# Altium schematic sheet 2_ESP32.SchDoc(2_ESP32)
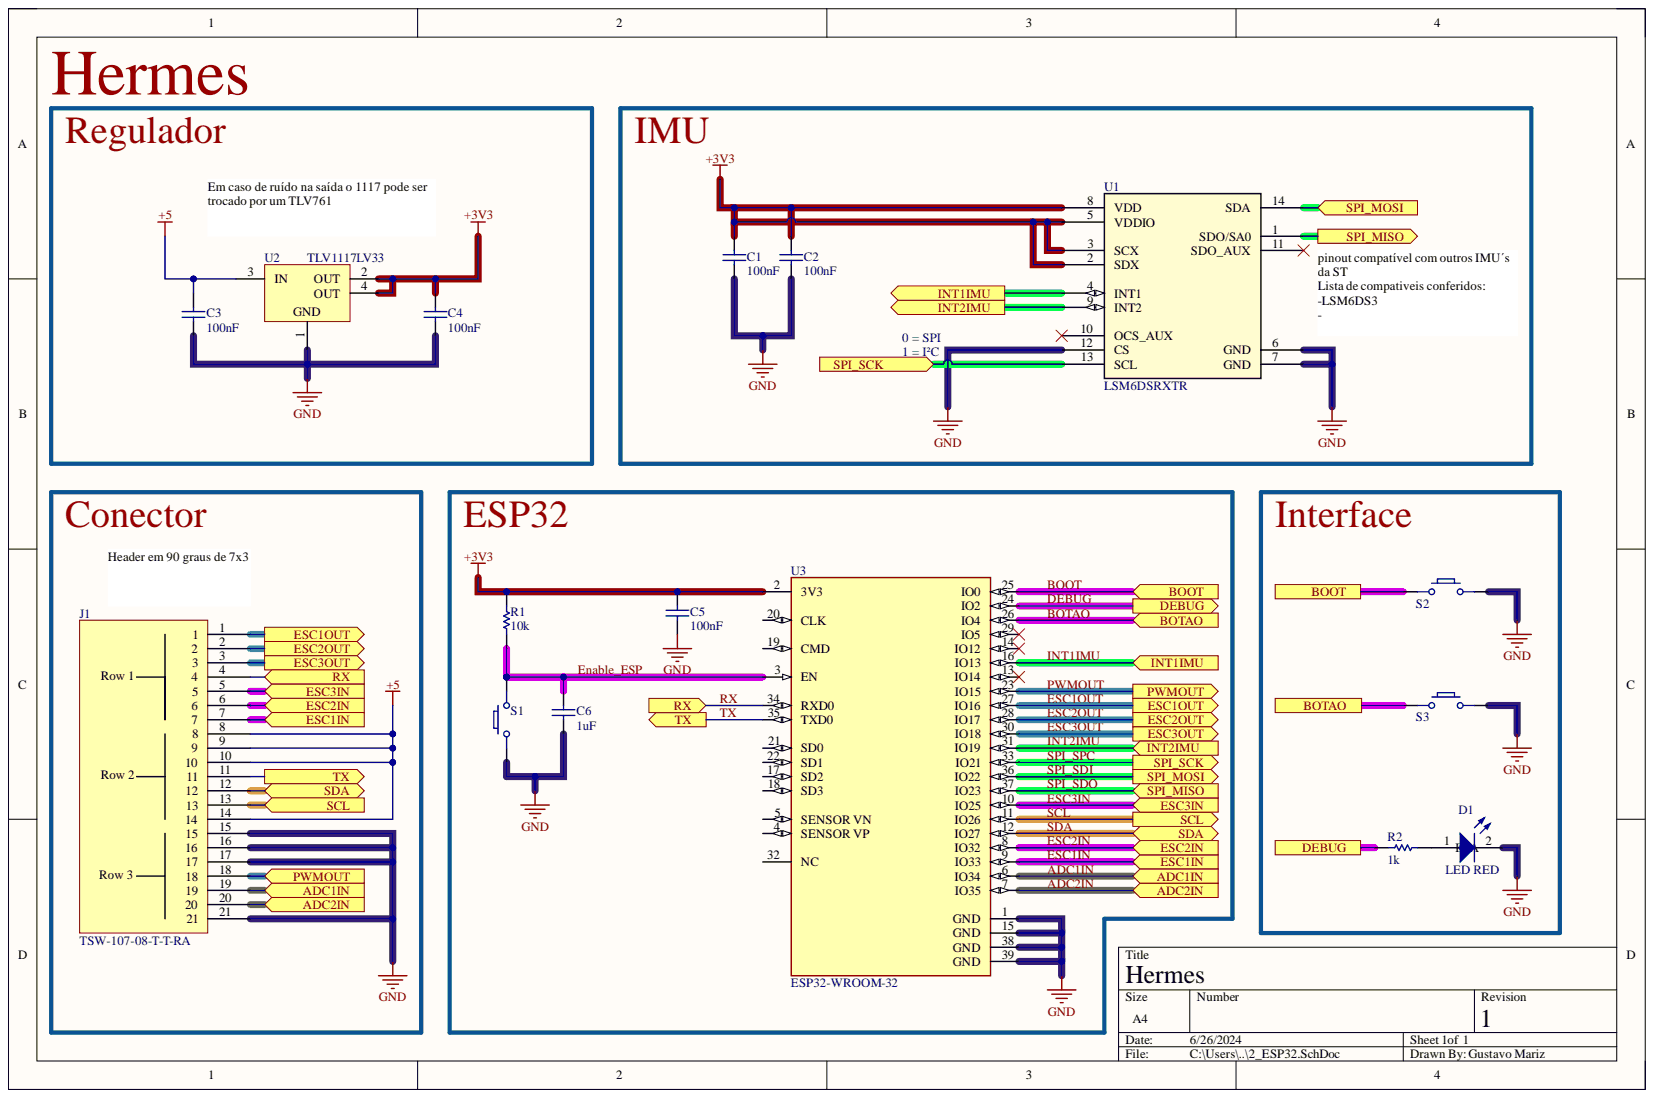
[diagram p0: 2_ESP32.SchDoc]

## Parts
C1  Valor: 100nF  [0603]  (hidden comment: CAP)
C2  Valor: 100nF  [0603]  (hidden comment: CAP)
C3  Valor: 100nF  [0603]  (hidden comment: CAP)
C4  Valor: 100nF  [0603]  (hidden comment: CAP)
C5  Valor: 100nF  [0603]  (hidden comment: CAP)
C6  Valor: 1uF  [0603]  (hidden comment: CAP)
D1  LED RED  [LED 0805]
J1  TSW-107-08-T-T-RA  [TSW-107-08-T-T-RA_SAI]
R1  value 10k  [RES 0603]  (hidden comment: RESISTOR)
R2  value 1k  [RES 0603]  (hidden comment: RESISTOR)
S1  PushButtonSMD  [PUSHBUTTON.SMD]  (value not drawn)
S2  PushButtonSMD  [PUSHBUTTON.SMD]  (value not drawn)
S3  PushButtonSMD  [PUSHBUTTON.SMD]  (value not drawn)
U1  LSM6DSRXTR  [LGA-14L_STM]
U2  TLV1117LV33  [DCY0004A_N]
U3  ESP32-WROOM-32  [FP-ESP32-WROOM-32-MFG]

## Ports
ADC1IN, ADC2IN, BOOT, BOTAO, DEBUG, ESC1IN, ESC1OUT, ESC2IN, ESC2OUT, ESC3IN, ESC3OUT, INT1IMU, INT2IMU, PWMOUT, RX, SCL, SDA, SPI_MISO, SPI_MOSI, SPI_SCK, TX

## Nets
+3V3: C1.2 C2.2 C4.2 C5.2 R1.2 U1.2(SDX) U1.3(SCX) U1.5(VDDIO) U1.8(VDD) U2.2(OUT) U2.4(OUT) U3.2(3V3)
+5: C3.2 J1.8 J1.9 J1.10 J1.14 U2.3(IN)
GND: C1.1 C2.1 C3.1 C4.1 C5.1 C6.1 D1.2 J1.15 J1.16 J1.17 J1.21 S1.2 S2.2 S3.2 U1.6(GND) U1.7(GND) U1.12(CS) U2.1 U3.1(GND) U3.15(GND) U3.38(GND) U3.39(GND)
ADC1IN: J1.19 U3.6(IO34) ; port ADC1IN
ADC2IN: J1.20 U3.7(IO35) ; port ADC2IN
BOOT: S2.1 U3.25(IO0) ; port BOOT
BOTAO: S3.1 U3.26(IO4) ; port BOTAO
DEBUG: R2.2 U3.24(IO2) ; port DEBUG
Enable_ESP: C6.2 R1.1 S1.1 U3.3(EN)
ESC1IN: J1.7 U3.9(IO33) ; port ESC1IN
ESC1OUT: J1.1 U3.27(IO16) ; port ESC1OUT
ESC2IN: J1.6 U3.8(IO32) ; port ESC2IN
ESC2OUT: J1.2 U3.28(IO17) ; port ESC2OUT
ESC3IN: J1.5 U3.10(IO25) ; port ESC3IN
ESC3OUT: J1.3 U3.30(IO18) ; port ESC3OUT
INT1IMU: U1.4(INT1) U3.16(IO13) ; port INT1IMU
INT2IMU: U1.9(INT2) U3.31(IO19) ; port INT2IMU
PWMOUT: J1.18 U3.23(IO15) ; port PWMOUT
RX: J1.4 U3.34(RXD0) ; port RX
SCL: J1.13 U3.11(IO26) ; port SCL
SDA: J1.12 U3.12(IO27) ; port SDA
SPI_SDI: U1.14(SDA) U3.36(IO22) ; port SPI_MOSI
SPI_SDO: U1.1(SDO/SA0) U3.37(IO23) ; port SPI_MISO
SPI_SPC: U1.13(SCL) U3.33(IO21) ; port SPI_SCK
TX: J1.11 U3.35(TXD0) ; port TX
NetD1_1: D1.1 R2.1

Not connected: U1 10(OCS_AUX) 11(SDO_AUX); U3 4(SENSOR_VP) 5(SENSOR_VN) 13(IO14) 14(IO12) 17(SD2) 18(SD3) 19(CMD) 20(CLK) 21(SD0) 22(SD1) 29(IO5) 32(NC)

## Library data of the parts
C1-C6 = CAP. CERÂMICO: Capacitor; Criador por: Luigi Eduardo; Pertence a: Trincabotz; Component Kind: Standard; Criado em: 26/06/2012; Library Name: Biblioteca Trincabotz (1).IntLib
D1 = LED: Component Kind: Standard; Library Name: Biblioteca Trincabotz (1).IntLib
J1 = TSW-107-08-T-T-RA: No Description Available; Mfr_Name: Samtec Inc; Component Kind: Standard; RefDes: RefDes; Type: Type; Library Name: 3x7pinheader.IntLib
U1 = LSM6DSRXTR: No Description Available; Mfr_Name: STMicroelectronics; Component Kind: Standard; Library Name: LSM6DSRXTR.IntLib; RefDes: RefDes; Type: Type
U2 = CMP-0395-00503-2: Single Output LDO, 1 A, Fixed 3.3 V Output, 2 to 5.5 V Input, 4-pin SOT-223 (DCY), -40 to 125 degC, Green (RoHS & no Sb/Br); Manufacturer: Texas Instruments; PartNumber: TLV1117LV33DCYR; Accuracy(%): 2; Fixed Output Options(V): 3.3; Iout(Max)(A): 1; Iq(Typ)(mA): 0.05; SubFamily: Single Channel LDO; Vdo(Typ)(mV): 1200; Vin(Max)(V): 5.5; Code_JEDEC: TO-261; Component Kind: Standard; ComponentLink1Description: Manufacturer URL; ComponentLink1URL: http://www.ti.com/; ComponentLink2Description: Datasheet; ComponentLink2URL: http://www.ti.com/general/docs/lit/getliterature.tsp?genericPartNumber=TLV1117LV33&fileType=pdf; ComponentLink3Description: Package Specification; ComponentLink3URL: http://www.ti.com/litv/pdf/mpds094a; DatasheetVersion: SBVS160A; Eco-Plan: Green (RoHS & no Sb/Br); Library Name: Altium Content Vault; Mounting Technology: Surface Mount; PackageDescription: 4-Pin Plastic Small Outline, Body 3.5 x 6.5 mm, Pitch 2.3 mm; PackageReference: DCY0004A; PackageVersion: 4202506/B; Vin(Min)(V): 2; Vout Adj(Max)(V): 3.3; Vout Adj(Min)(V): 3.3
U3 = CMP-194065-000008-1: RX TXRX MOD WIFI SURFACE MOUNT; Data Rate: 150Mbps; Frequency: 2.5GHz; Operating Supply Voltage: 3.6V; Sensitivity: -98dBm; Case/Package: SMD/SMT; Component Kind: Standard; Library Name: Altium Content Vault; Max Operating Temperature: 85°C; Min Operating Temperature: -40°C; Mount: Surface Mount; Package Quantity: 550; Packaging: Cut Tape; Weight: 0.088185oz
R1 R2 = RESISTOR: Component Kind: Standard; Description: Resistor; Library Name: Biblioteca Trincabotz (1).IntLib
S1-S3 = PushButtonSMD: Component Kind: Standard; Library Name: Biblioteca Trincabotz (1).IntLib
